# Altium schematic sheet atmusic.SchDoc(atmusic)
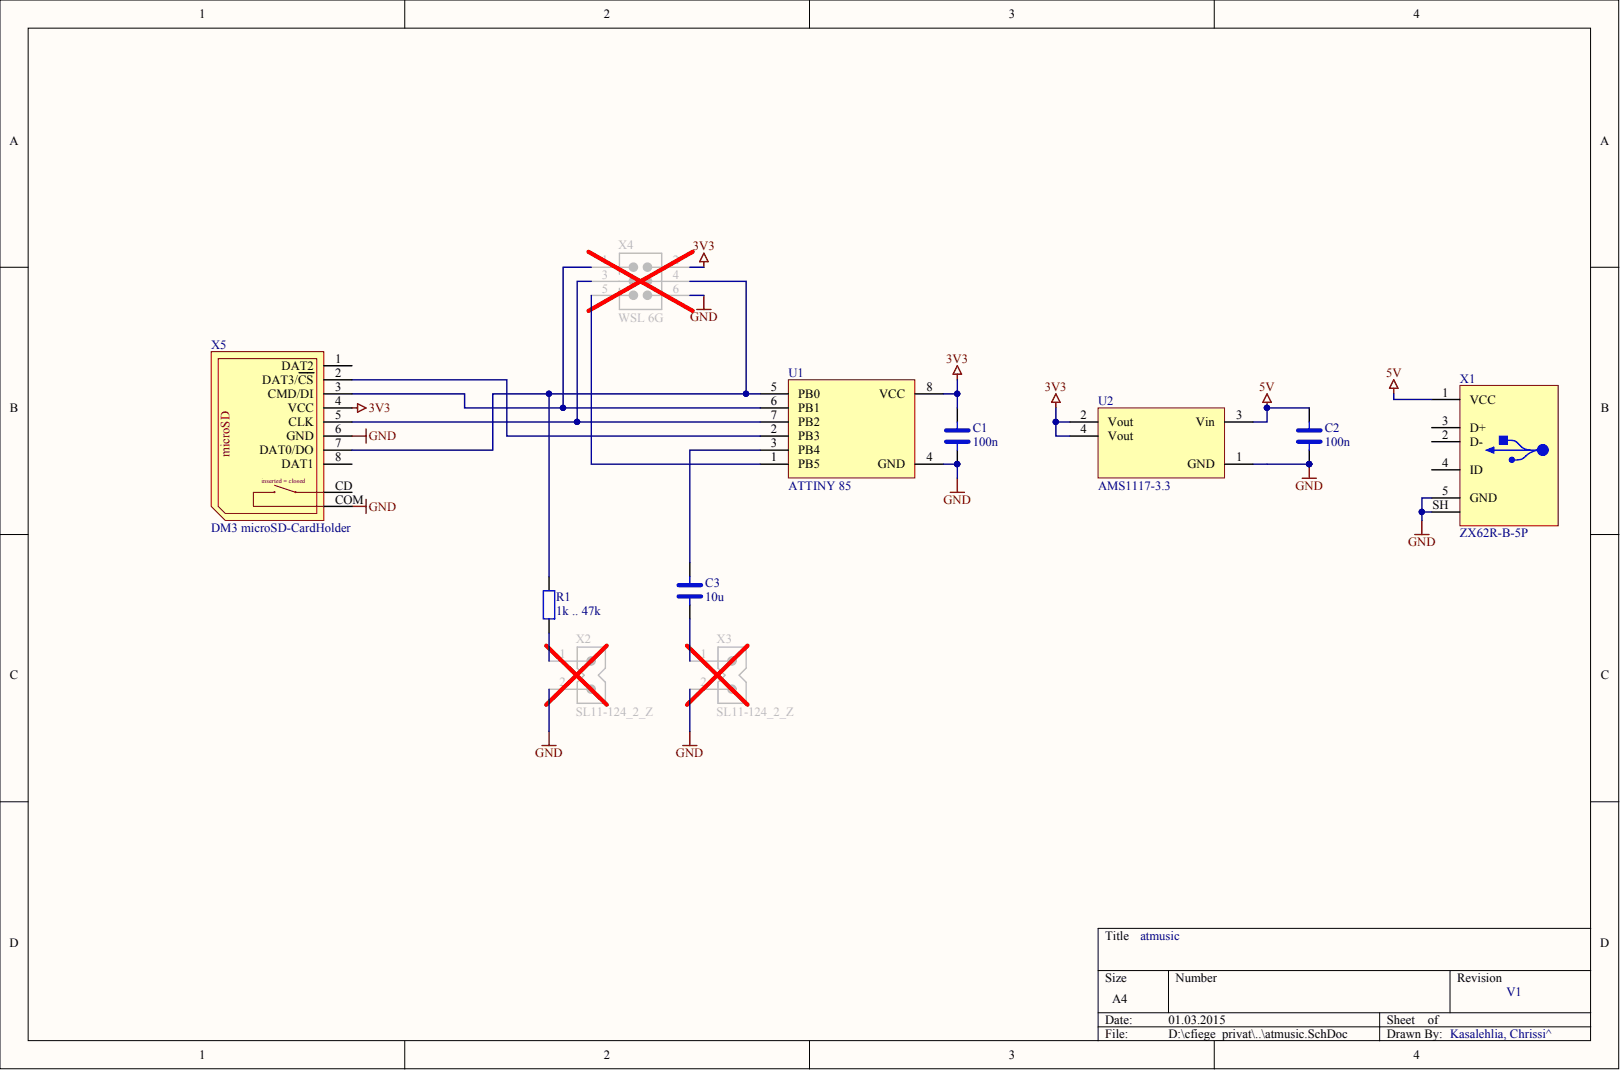
[diagram p0: atmusic.SchDoc]

## Parts
C1  100n  [CAPC1608X06N]
C2  100n  [CAPC1608X06N]
C3  10u  [CAPC3216X07N]
R1  1k .. 47k  [RESC1608X06N]
U1  ATTINY 85  [SOIC127P800X216-8N]
U2  AMS1117-3.3  [SOT230P700X180-4N]
X1  ZX62R-B-5P  [ZX62R-B-5P]
X2  SL11-124_2_Z  [Fischer_SL11-124_2_x]
X3  SL11-124_2_Z  [Fischer_SL11-124_2_x]
X4  WSL 6G  [WSL_6G]
X5  DM3 microSD-CardHolder  [DM3CS-SF]

## Nets
3V3: C1.2 U1.8(VCC) U2.2(Vout) U2.4(Vout) X4.2 X5.4(VCC)
5V: C2.2 U2.3(Vin) X1.1(VCC)
GND: C1.1 C2.1 U1.4(GND) U2.1(GND) X1.5(GND) X1.SH X2.2 X3.2 X4.6 X5.6(GND) X5.COM
NetC3_1: C3.1 X3.1
NetC3_2: C3.2 U1.3(PB4)
NetR1_1: R1.1 X2.1
NetR1_2: R1.2 U1.5(PB0) X4.4 X5.7(DAT0/DO)
NetU1_1: U1.1(PB5) X4.5
NetU1_2: U1.2(PB3) X5.2(DAT3/CS)
NetU1_6: U1.6(PB1) X4.1 X5.3(CMD/DI)
NetU1_7: U1.7(PB2) X4.3 X5.5(CLK)
NetX1_2: X1.2(D-)
NetX1_3: X1.3(D+)
NetX1_4: X1.4(ID)
NetX5_1: X5.1(DAT2)
NetX5_8: X5.8(DAT1)
NetX5_CD: X5.CD

## Library data of the parts
C1 C2 = X7R-G0603 100N: 100nF ±10% 0603 X7R Chip Capacitor, 16V; Bauteilart: Kondensator; Database Table Name: HSC_Library; Hersteller: Murata; Kategorie: Bauteil; Polar: u; Unterart: SMD-Vielschicht X7R; Component Kind: Standard; ComponentLink1Description: Datenblatt; ComponentLink2Description: Datenblatt; Distributor_1: Reichelt; Distributor_1_Part_Number: X7R-G0603 100N; Distributor_1_Preis: 0,05; Mounting: SMT; PackageDescription: 0603; Part Number: X7R-G0603 100N; Value: 100n; ComponentLink2URL: D:\Elektronik\PCB_Bibliothek\Reichelt\Datenblaetter\Kondensatoren\VielschichtX7R.pdf; Library Name: Reichelt.dblib
C3 = X7R-G1206 10/25: 10uF ±10% 1206 X7R Chip Capacitor, 25V; Bauteilart: Kondensator; Database Table Name: HSC_Library; Hersteller: Murata; Kategorie: Bauteil; Polar: u; Unterart: MURATA High Caps X7R; Component Kind: Standard; ComponentLink1Description: Datenblatt; ComponentLink2Description: Datenblatt; Mounting: SMT; PackageDescription: 1206; Part Number: X7R-G1206 10/25; Value: 10u; ComponentLink2URL: D:\Elektronik\PCB_Bibliothek\Reichelt\Datenblaetter\Kondensatoren\MurataC02e.pdf; Library Name: Reichelt.dblib
R1 = SMD R 0603: 1% 100mW 0603 Chip Resistor E12; Bauteilart: Widerstand; Database Table Name: HSC_Library; Kategorie: Bauteil; Polar: u; Unterart: SMD; Component Kind: Standard; ComponentLink1Description: Datenblatt; ComponentLink2Description: Datenblatt; Distributor_1: Reichelt; Distributor_1_Preis: 0,1; Mounting: SMT; PackageDescription: 0603; Part Number: SMD R 0603; Value: 1k .. 47k; ComponentLink2URL: D:\Elektronik\PCB_Bibliothek\Reichelt\Datenblaetter\; Library Name: Reichelt.dblib
U1 = ATTINY 85-20 SU: 8-Bit Microcontroller with 8kBytes Flash; Bauteilart: IC; Database Table Name: HSC_Library; Ersteller: cf; Hersteller: Microchip; Kategorie: Bauteil; Polar: p; Component Kind: Standard; ComponentLink1Description: Datenblatt; ComponentLink2Description: Datenblatt; Mounting: SMT; PackageDescription: SOIC8; Part Number: ATTINY 85-20 SU; Unterart: Controller; Value: ATTINY 85; ComponentLink2URL: D:\Elektronik\PCB_Bibliothek\Reichelt\Datenblaetter\IC\ATTINY85.pdf; Library Name: Reichelt.dblib
U2 = AMS1117-3.3: Low cost fixed linear regulator; Bauteilart: IC; Database Table Name: HSC_Library; Ersteller: cf; Hersteller: AMS; Kategorie: Bauteil; Polar: p; Unterart: Linear Regler; Component Kind: Standard; ComponentLink1Description: Datenblatt; Distributor_1: ebay; Distributor_2_Preis: 0,25; Erstelldatum: 01.03.2015; Hersteller_Code_1: AMS1117-3.3; Library Name: Other.dblib; Mounting: SMT; PackageDescription: SOT-223; Part Number: AMS1117-3.3; Value: AMS1117-3.3; ComponentLink1URL: D:\Elektronik\PCB_Bibliothek\Other\Datenblaetter\AMS1117.pdf
X1 = ZX62R-B-5P: Micro-USB, SMT, Reverse; Bauteilart: Steckverbinder; Database Table Name: HSC_Library; Ersteller: avd; Hersteller: Hirose; Kategorie: Bauteil; Polar: p; Unterart: USB; Component Kind: Standard; ComponentLink1Description: Datenblatt; Distributor_1: Farnell; Distributor_1_Part_Number: 2300439; Distributor_1_Preis: 1,8; Erstelldatum: 16.02.2014; Hersteller_Code_1: ZX62R-B-5P; Library Name: Other.dblib; Mounting: SMT; PackageDescription: SMT, 5-Pol; Part Number: ZX62R-B-5P; Value: ZX62R-B-5P; ComponentLink1URL: D:\Elektronik\PCB_Bibliothek\Other\Datenblaetter\Hirose_ZX_Series.pdf
X2 X3 = SL11-124_2_Z: Stiftleiste THT 2 reihig Rastermaß 2,54mm; Bauteilart: Steckverbinder; Database Table Name: HSC_Library; Ersteller: gserhorn; Freigabe: unchecked; Hersteller: Fischerelektronik; Kategorie: Bauteil; Component Kind: Standard; ComponentLink1Description: Datasheet; Erstelldatum: 05.11.2010; Hersteller_Code_1: SL11-124_2_Z; Library Name: Altium_lib.DbLib; Mounting: THT; PackageDescription: Stiftleiste 2 pol. 2,54mm Rastermaß; Part Number: SL11-124_2_Z; Unterart: Stiftleiste; Value: SL11-124_2_Z; ComponentLink1URL: D:\HSC_Bibliothek\Datenblaetter\pcb\Steckverbinder\Fischerelektronik\
X4 = WSL 6G: Wannenstecker, 6Pol, 2,54mm RM; Bauteilart: Steckverbinder; Database Table Name: HSC_Library; Ersteller: cf; Hersteller: diverse; Kategorie: Bauteil; Component Kind: Standard; Mounting: THT; PackageDescription: Wanne, 6Pol; Part Number: WSL 6G; Unterart: Wannenstecker; Value: WSL 6G; Library Name: Reichelt.dblib
X5 = DM3CS-SF: DM3-Series microSD Memory-Card Hinge Connector (Push-Pull, no election); Bauteilart: Steckverbinder; Database Table Name: HSC_Library; Ersteller: gmbaetje; Freigabe: unchecked; Hersteller: Hirose; Hersteller_Code_1: CL609-0032-3; Kategorie: Bauteil; Polar: p; Unterart: Kartenhalter; Component Kind: Standard; ComponentLink1Description: Datasheet; Erstelldatum: 01.10.2010; Hersteller_Code_1_packaging: Reel 1300; Library Name: Altium_lib.DbLib; Mounting: SMT; PackageDescription: DM3 Series Standard-Package; Part Number: DM3CS-SF; Value: DM3 microSD-CardHolder; ComponentLink1URL: D:\HSC_Bibliothek\Datenblaetter\pcb\Steckverbinder\\Hirose\DM3-Series.pdf
